# Altium schematic sheet Sheet1.SchDoc
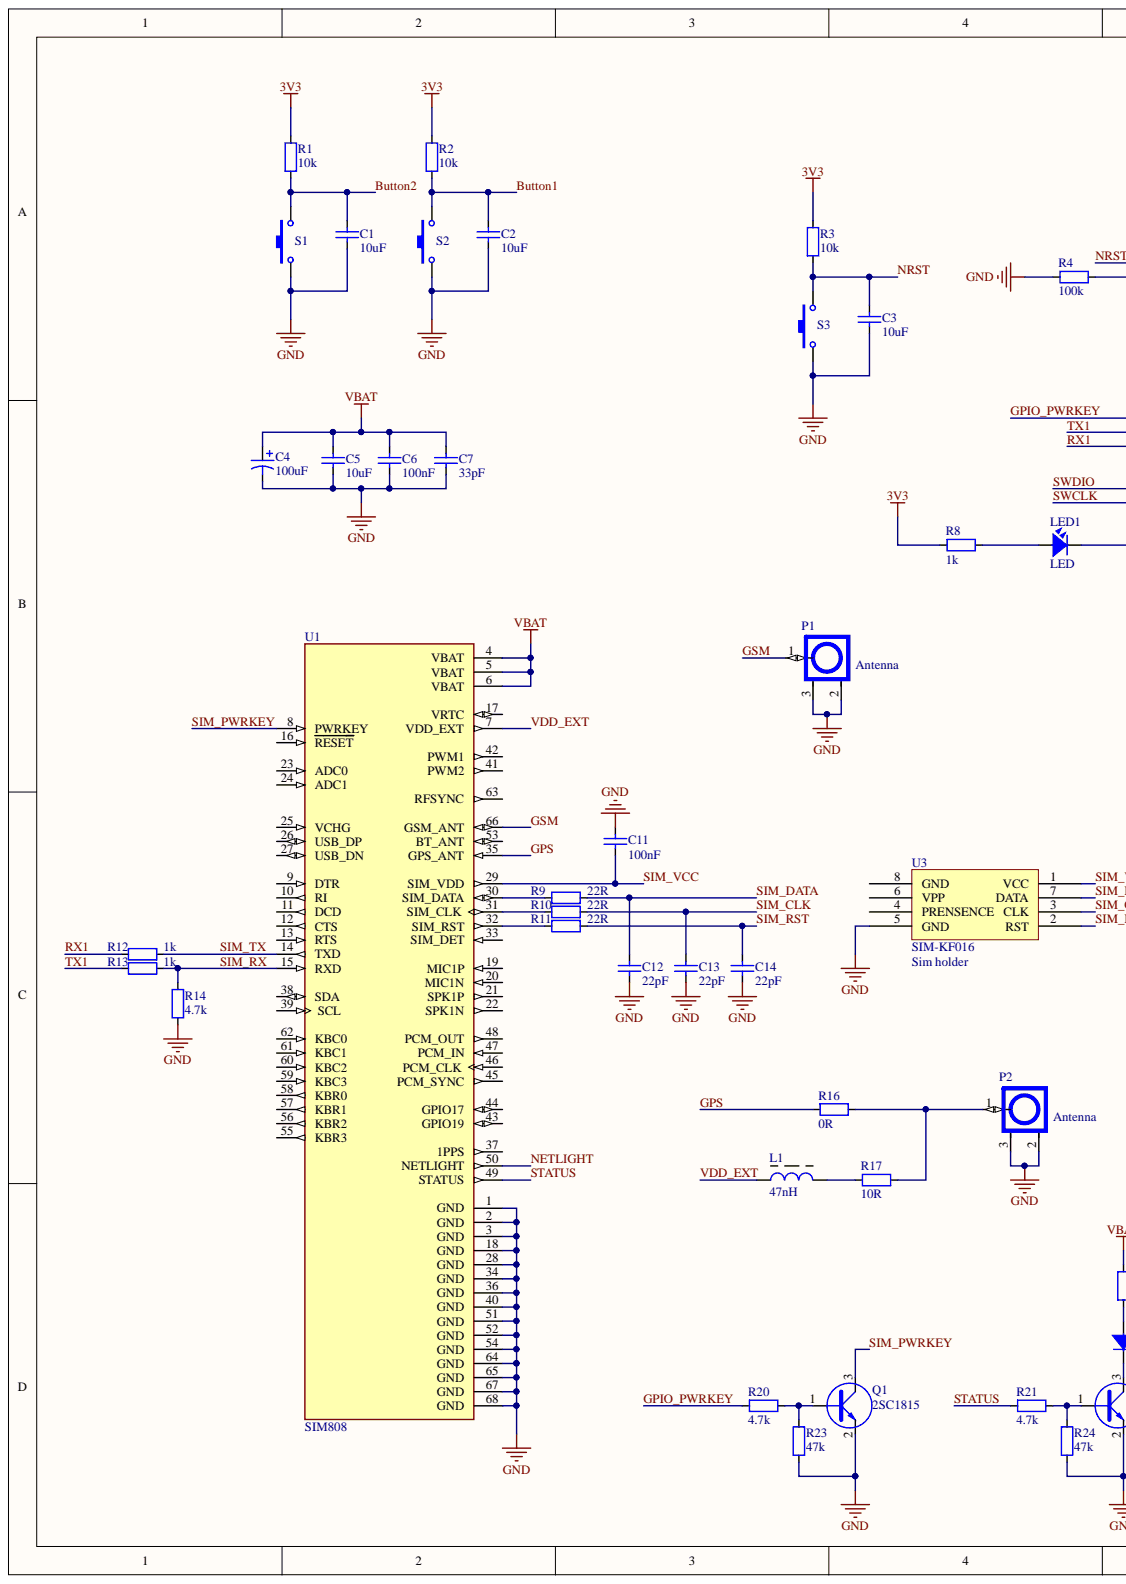
[diagram p0: Sheet1.SchDoc, left]
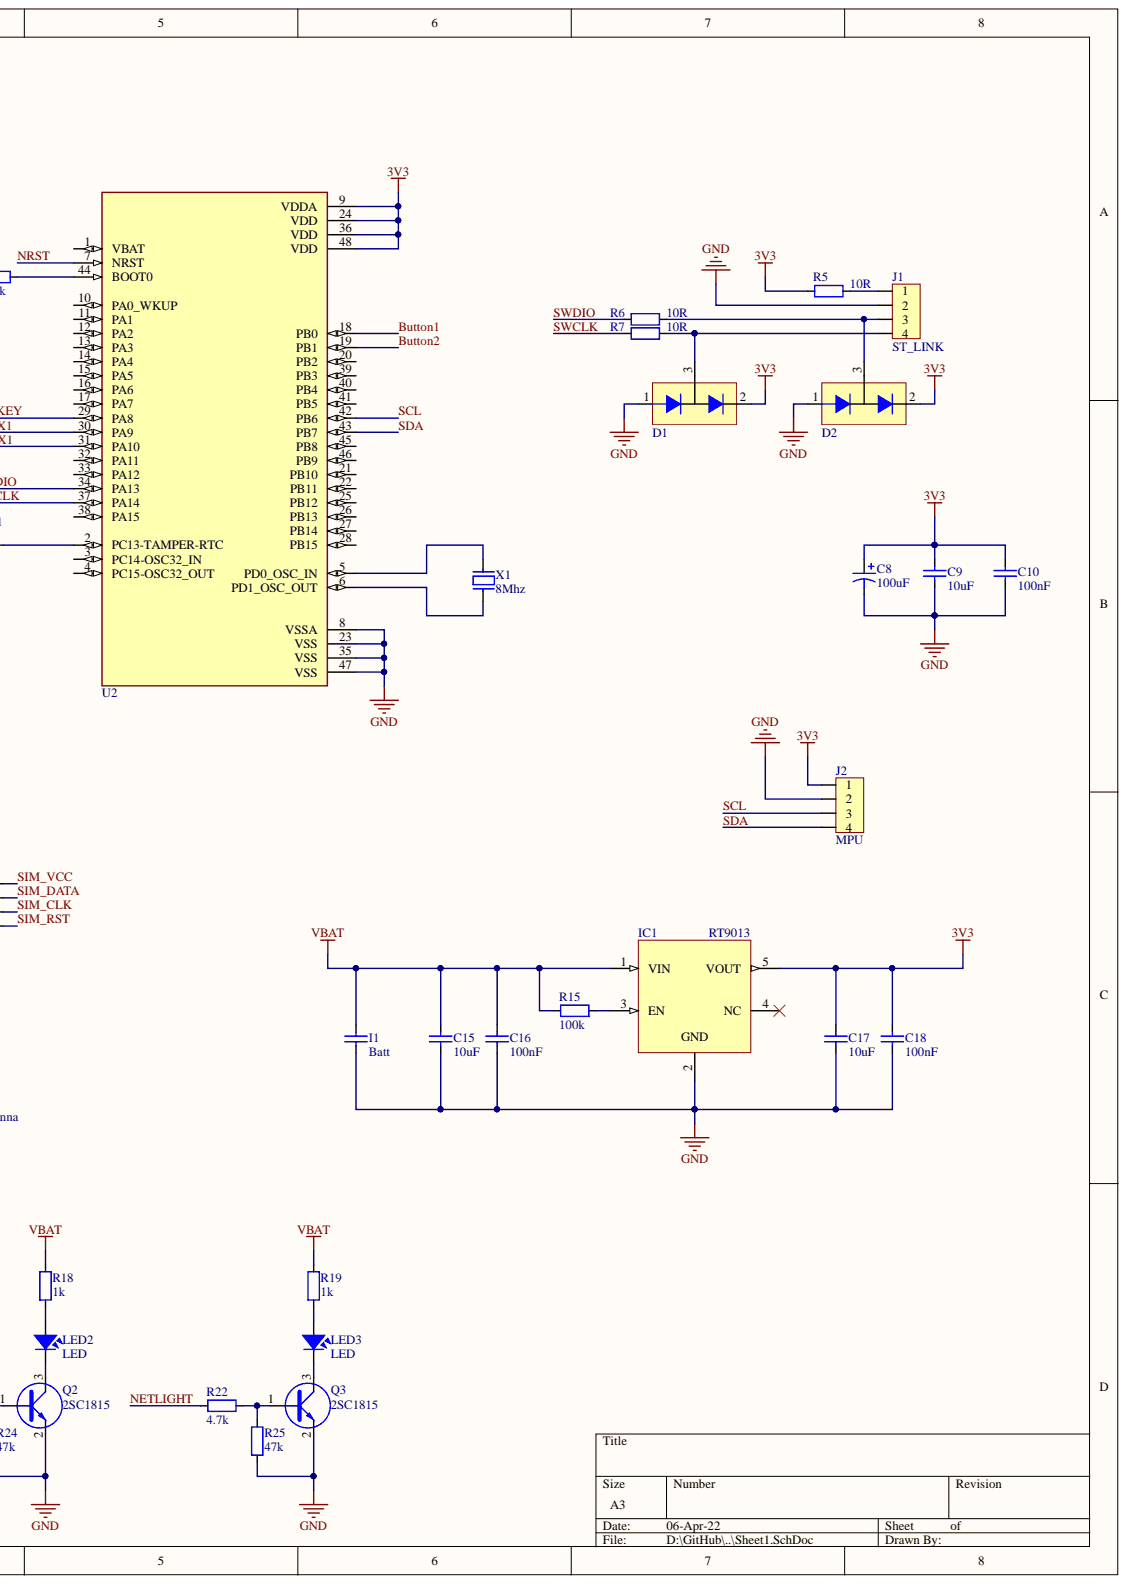
[diagram p1: Sheet1.SchDoc, right]

## Parts
C1  10uF  [CAP_0603]
C2  10uF  [CAP_0603]
C3  10uF  [CAP_0603]
C4  100uF  [CAP_7343]
C5  10uF  [CAP_0603]
C6  100nF  [CAP_0603]
C7  33pF  [CAP_0603]
C8  100uF  [CAP_7343]
C9  10uF  [CAP_0603]
C10  100nF  [CAP_0603]
C11  100nF  [CAP_0603]
C12  22pF  [CAP_0603]
C13  22pF  [CAP_0603]
C14  22pF  [CAP_0603]
C15  10uF  [CAP_0603]
C16  100nF  [CAP_0603]
C17  10uF  [CAP_0603]
C18  100nF  [CAP_0603]
D1  DIODE-BAV99  [SOT23]  (value not drawn)
D2  DIODE-BAV99  [SOT23]  (value not drawn)
I1  Batt  [CAP_3216]
IC1  RT9013  [SOT23-5]
J1  ST_LINK  [JP4-D1T]
J2  MPU  [JP4-D1T]
L1  47nH  [L-0805]
LED1  LED  [LED-0805-Orange]
LED2  LED  [LED-0805-Yellow]
LED3  LED  [LED-0805-Red]
P1  Antenna  [IPXS]
P2  Antenna  [IPXS]
Q1  2SC1815  [SOT23D]
Q2  2SC1815  [SOT23D]
Q3  2SC1815  [SOT23D]
R1  10k  [RES-SMD-0603]
R2  10k  [RES-SMD-0603]
R3  10k  [RES-SMD-0603]
R4  100k  [RES-SMD-0603]
R5  10R  [RES-SMD-0603]
R6  10R  [RES-SMD-0603]
R7  10R  [RES-SMD-0603]
R8  1k  [RES-SMD-0603]
R9  22R  [RES-SMD-0603]
R10  22R  [RES-SMD-0603]
R11  22R  [RES-SMD-0603]
R12  1k  [RES-SMD-0603]
R13  1k  [RES-SMD-0603]
R14  4.7k  [RES-SMD-0603]
R15  100k  [RES-SMD-0603]
R16  0R  [RES-SMD-0805]
R17  10R  [RES-SMD-0805]
R18  1k  [RES-SMD-0603]
R19  1k  [RES-SMD-0603]
R20  4.7k  [RES-SMD-0603]
R21  4.7k  [RES-SMD-0603]
R22  4.7k  [RES-SMD-0603]
R23  47k  [RES-SMD-0603]
R24  47k  [RES-SMD-0603]
R25  47k  [RES-SMD-0603]
S1  SW-SMD  [SW 3x2x4]  (value not drawn)
S2  SW-SMD  [SW 3x2x4]  (value not drawn)
S3  SW-SMD  [SW 3x2x4]  (value not drawn)
U1  SIM808  [XCVR_SIM808]
U2  STM32  [LQFP 48]  (value not drawn)
U3  SIM-KF016  value Sim holder  [Sim Socket]
X1  8Mhz  [XTAL 5032 SMD]

## Nets
3V3: C8.1 C9.2 C10.2 C17.2 C18.2 D1.2 D2.2 IC1.5(VOUT) J2.1 R1.2 R2.2 R3.2 R5.1 R8.1 U2.9(VDDA) U2.24(VDD) U2.36(VDD) U2.48(VDD)
GND: C1.1 C2.1 C3.1 C4.2 C5.1 C6.1 C7.1 C8.2 C9.1 C10.1 C11.1 C12.2 C13.2 C14.2 C15.1 C16.1 C17.1 C18.1 D1.1 D2.1 I1.1 IC1.2 J1.2 J2.2 P1.2 P1.3 P2.2 P2.3 Q1.2 Q2.2 Q3.2 R4.2 R14.2 R23.1 R24.1 R25.1 S1.1 S2.1 S3.1 U1.1(GND) U1.2(GND) U1.3(GND) U1.18(GND) U1.28(GND) U1.34(GND) U1.36(GND) U1.40(GND) U1.51(GND) U1.52(GND) U1.54(GND) U1.64(GND) U1.65(GND) U1.67(GND) U1.68(GND) U2.8(VSSA) U2.23(VSS) U2.35(VSS) U2.47(VSS) U3.5(GND)
VBAT: C4.1 C5.2 C6.2 C7.2 C15.2 C16.2 I1.2 IC1.1(VIN) R15.2 R18.2 R19.2 U1.4(VBAT) U1.5(VBAT) U1.6(VBAT)
Button1: C2.2 R2.1 S2.2 U2.18(PB0)
Button2: C1.2 R1.1 S1.2 U2.19(PB1)
GPIO_PWRKEY: R20.1 U2.29(PA8)
GPS: R16.1 U1.35(GPS_ANT)
GSM: P1.1 U1.66(GSM_ANT)
NETLIGHT: R22.1 U1.50(NETLIGHT)
NRST: C3.2 R3.1 S3.2 U2.7(NRST)
RX1: R12.2 U2.31(PA10)
SCL: J2.3 U2.42(PB6)
SDA: J2.4 U2.43(PB7)
SIM_CLK: C13.1 R10.1 U3.3(CLK)
SIM_DATA: C12.1 R9.1 U3.7(DATA)
SIM_PWRKEY: Q1.3 U1.8(PWRKEY)
SIM_RST: C14.1 R11.1 U3.2(RST)
SIM_RX: R13.1 R14.1 U1.15(RXD)
SIM_TX: R12.1 U1.14(TXD)
SIM_VCC: C11.2 U1.29(SIM_VDD) U3.1(VCC)
STATUS: R21.1 U1.49(STATUS)
SWCLK: R7.1 U2.37(PA14)
SWDIO: R6.1 U2.34(PA13)
TX1: R13.2 U2.30(PA9)
VDD_EXT: L1.1 U1.7(VDD_EXT)
NetD1_3: D1.3 J1.4 R7.2
NetD2_3: D2.3 J1.3 R6.2
NetIC1_3: IC1.3(EN) R15.1
NetJ1_1: J1.1 R5.2
NetL1_2: L1.2 R17.2
NetLED1_1: LED1.1 R8.2
NetLED1_2: LED1.2 U2.2(PC13-TAMPER-RTC)
NetLED2_1: LED2.1 R18.1
NetLED2_2: LED2.2 Q2.3
NetLED3_1: LED3.1 R19.1
NetLED3_2: LED3.2 Q3.3
NetP2_1: P2.1 R16.2 R17.1
NetQ1_1: Q1.1 R20.2 R23.2
NetQ2_1: Q2.1 R21.2 R24.2
NetQ3_1: Q3.1 R22.2 R25.2
NetR4_1: R4.1 U2.44(BOOT0)
NetR9_2: R9.2 U1.30(SIM_DATA)
NetR10_2: R10.2 U1.31(SIM_CLK)
NetR11_2: R11.2 U1.32(SIM_RST)
NetU2_5: U2.5(PD0_OSC_IN) X1.2
NetU2_6: U2.6(PD1_OSC_OUT) X1.1

Not connected: IC1 4(NC); U1 9(DTR) 10(RI) 11(DCD) 12(CTS) 13(RTS) 16(RESET) 17(VRTC) 19(MIC1P) 20(MIC1N) 21(SPK1P) 22(SPK1N) 23(ADC0) 24(ADC1) 25(VCHG) 26(USB_DP) 27(USB_DN) 33(SIM_DET) 37(1PPS) 38(SDA) 39(SCL) 41(PWM2) 42(PWM1) 43(GPIO19) 44(GPIO17) 45(PCM_SYNC) 46(PCM_CLK) 47(PCM_IN) 48(PCM_OUT) 53(BT_ANT) 55(KBR3) 56(KBR2) 57(KBR1) 58(KBR0) 59(KBC3) 60(KBC2) 61(KBC1) 62(KBC0) 63(RFSYNC); U2 1(VBAT) 3(PC14-OSC32_IN) 4(PC15-OSC32_OUT) 10(PA0_WKUP) 11(PA1) 12(PA2) 13(PA3) 14(PA4) 15(PA5) 16(PA6) 17(PA7) 20(PB2) 21(PB10) 22(PB11) 25(PB12) 26(PB13) 27(PB14) 28(PB15) 32(PA11) 33(PA12) 38(PA15) 39(PB3) 40(PB4) 41(PB5) 45(PB8) 46(PB9); U3 4(PRENSENCE) 6(VPP) 8(GND)

## Library data of the parts
C1-C3 C5-C7 C9-C18 = CapSMD: Capacitor; Value: 33p; Component Kind: Standard; LatestRevisionDate: 17-Jul-2002; LatestRevisionNote: Re-released for DXP Platform.; Library Name: My Library.SchLib; PackageReference: RAD-0.3; Published: 8-Jun-2000; Publisher: Altium Limited
C4 C8 = Cap Pol: Tantalum; Component Kind: Standard; LatestRevisionDate: 17-Jul-2002; LatestRevisionNote: Re-released for DXP Platform.; Library Name: My Library.SchLib; PackageReference: POLAR0.8; Published: 8-Jun-2000; Publisher: Altium Limited
D1 D2 = DIODE-BAV99: ZENER, SOT23,; Manufacturer: ON Semiconductor / Fairchild; Manufacturer Part Number: BAV99; .CheckPCB: LeKhaLinh; Current - Average Rectified (Io) (per Diode): 200mA; Current - Reverse Leakage @ Vr: 2.5µA @ 70V; Diode Configuration: 1 Pair Series Connection; Diode Type: Standard; Online Catalog: Diode Array; Other Drawings: Diode Circuit; Other Names: BAV99FSDKR; PCN Assembly/Origin: SOT23 Manufacturing Source 31/May2013    Assembly Site Addition 20/Jul/2015; PCN Design/Specification: Mold Compound 12/Dec/2007; PCN Packaging: Binary Year Code Marking 15/Jan/2014; Reverse Recovery Time (trr): 6ns; Speed: Small Signal =; Voltage - DC Reverse (Vr) (Max): 70V; Voltage - Forward (Vf) (Max) @ If: 1.25V @ 150mA; Category: Discrete Semiconductor Products; Component Kind: Standard; ComponentLink1Description: https://www.fairchildsemi.com/package-drawings/MA/MA03B.pdf?src-supplier=Digi-Key; ComponentLink1URL: https://www.fairchildsemi.com/package-drawings/MA/MA03B.pdf?src-supplier=Digi-Key; ComponentLink2Description: http://www.farnell.com/datasheets/1822674.pdf?src-supplier=Element14; ComponentLink2URL: http://www.farnell.com/datasheets/1822674.pdf?src-supplier=Element14; ComponentLink3Description: http://www.mouser.com/ds/2/149/BAV99-22788.pdf?src-supplier=Mouser; ComponentLink3URL: http://www.mouser.com/ds/2/149/BAV99-22788.pdf?src-supplier=Mouser; ComponentLink4Description: http://www.fairchildsemi.com/ds/BA/BAV99.pdf?src-supplier=Avnet+Europe; ComponentLink4URL: http://www.fairchildsemi.com/ds/BA/BAV99.pdf?src-supplier=Avnet+Europe; ComponentLink5Description: https://www.verical.com/server-webapp/html/getDatasheet?partID=110217&src-supplier=Verical; ComponentLink5URL: https://www.verical.com/server-webapp/html/getDatasheet?partID=110217&src-supplier=Verical; ComponentLink6Description: http://www.farnell.com/datasheets/2304409.pdf?src-supplier=Element14; ComponentLink6URL: http://www.farnell.com/datasheets/2304409.pdf?src-supplier=Element14; Family: Diodes, Rectifiers - Arrays; Mounting Type: Surface Mount; Package / Case: TO-236-3, SC-59, SOT-23-3; Packaging: Digi-Reel®; Pricing 1: 1=0.26, 10=0.21, 100=0.1115, 500=0.0734, 1000=0.0499 (USD); Pricing 3: 1=0.1933, 10=0.1873, 25=0.1555, 100=0.0806, 250=0.0724, 500=0.0523, 1000=0.0428, 3000=0.0342 (USD); Standard Package: 1; Stock 1: 1165; Stock 2: 0; Stock 3: 5700; Supplier 1: Digi-Key; Supplier 2: Mouser; Supplier 3: Arrow; Supplier Device Package: SOT-23-3 (TO-236); Supplier Part Number 1: BAV99FSCT-ND; Supplier Part Number 2: 512-BAV99; Supplier Part Number 3: BAV99; .CheckSCH: LeKhaLinh; .Creator: LeKhaLinh; Library Name: GPS_Traking_V1_1.IntLib
I1 = CapSMD: Pad; Value: 33p; Component Kind: Standard; LatestRevisionDate: 17-Jul-2002; LatestRevisionNote: Re-released for DXP Platform.; Library Name: My Library.SchLib; PackageReference: RAD-0.3; Published: 8-Jun-2000; Publisher: Altium Limited
IC1 = RT9013: RT9013-33GB IC REG LINEAR 3.3V 500mA SOT23-5; Component Kind: Standard; Library Name: My Library.SchLib
J1 J2 = Header4: Header IDC, 4 x 1 Pin Vertical 2.54mm Pitch (Non-Boxed, Male); Manufacturer: W¨¹rth Elektronik eiSos GmbH; partNo: 613002143121; ComponentLink1 Description: Manufacturer; ComponentLink2 Description: Datasheet; NoPins: 02; Component Kind: Standard; ComponentLink1URL: http://www.we-online.com; ComponentLink2URL: http://katalog.we-online.de/em/datasheet/6130xx143121.pdf; Created Date: 29 March 2013; Library Name: My Library.SchLib; Created by: Karrer Zheng
L1 = Inductor Ferit: Inductor; Value: 150 mH; Component Kind: Standard; Library Name: My Library.SchLib
LED1-LED3 = LED: LED Single colour Green and Red; Value: LED 3 ly Single Colour; Component Kind: Standard; Library Name: My Library.SchLib
P1 P2 = IPXSx66: Antenna GSM GPS; Component Kind: Standard; Library Name: My Library.SchLib
Q1-Q3 = 2SC1815: NPN 600mW, ECB; Value: C1815; : C828; : C2383; Component Kind: Standard; Library Name: My Library.SchLib
R1-R25 = Resistor - SMD: Resistor; Manufacturer: Generic Components; Class: Passive; Component Kind: Standard; Library Name: My Library.SchLib; Published: 08/06/2000; Publisher: Altium Hobart Technology Centre
S1-S3 = SW-SMD: Switch; Component Kind: Standard; LatestRevisionDate: 17-Jul-2002; LatestRevisionNote: Re-released for DXP Platform.; Library Name: My Library.SchLib; PackageReference: SPST-2; Published: 24-Mar-1999; Publisher: Altium Limited
U1 = SIM808: SIM808 GPS, GPRS/GSM RF Arduino Platform Evaluation Expansion Board; MF: Adafruit Industries; Availability: Unavailable; Component Kind: Standard; ComponentLink1Description: https://banlinhkien.com/sim808-p6650749.html; ComponentLink1URL: https://banlinhkien.com/sim808-p6650749.html; Library Name: My Library.SchLib; MP: SIM808; Package: None; Price: None; SnapEDA_Link: https://www.snapeda.com/parts/SIM808/SIMcom%20Wireless%20solutions%20Co.%2CLtd/view-part/424834/?ref=snap
U2 = STM32F103C8T6: STM32 ARM-based 32-bit MCU with 64 Kbytes Flash, 64-pin LQFP, Industrial Temperature; Component Kind: Standard; Library Name: My Library.SchLib
U3 = Sim holder: SIM Card Holder KF-016; Component Kind: Standard; Library Name: My Library.SchLib
X1 = XT2: Crystal For all Crystal 2pin.; Value: 4Mhz; Component Kind: Standard; Library Name: My Library.SchLib
